# Altium schematic sheet HAL_2.SchDoc
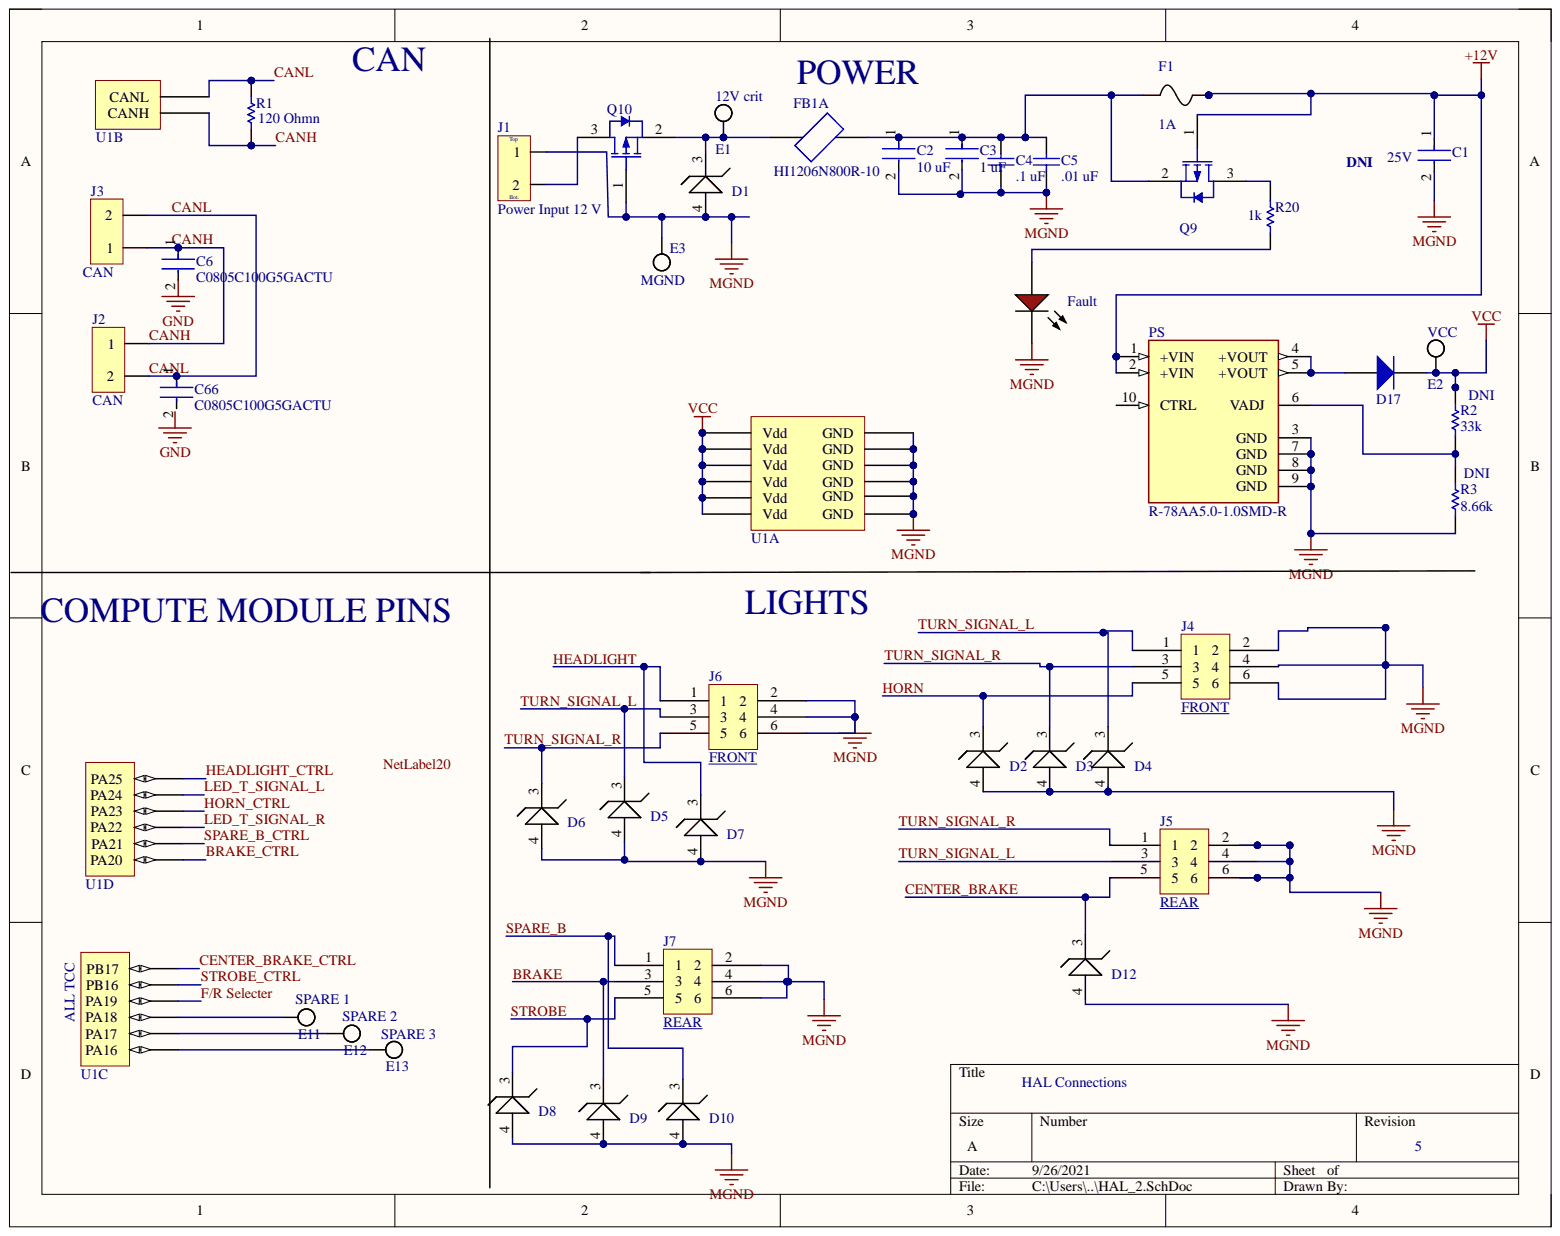
[diagram p0: HAL_2.SchDoc]

## Parts
C1  25V  [FP-GRM21B-0_2-e0_2_0_7-IPC_B]
C2  10 uF  [FP-CL31-IPC_A]
C3  1 uF  [FP-CL31-IPC_A]
C4  .1 uF  [CAPC2013X140X50LL20T25]
C5  .01 uF  [CAPC1608X90X35LL15T15]
C6  C0805C100G5GACTU  [FP-C0805C-DN-MFG]
C66  C0805C100G5GACTU  [FP-C0805C-DN-MFG]
D1  TVS DIODE 12.4V 20.1V DO219AB  [TVS DIODE 12.4V 20.1V DO219AB]  (value not drawn)
D2  TVS DIODE 12.4V 20.1V DO219AB  [TVS DIODE 12.4V 20.1V DO219AB]  (value not drawn)
D3  TVS DIODE 12.4V 20.1V DO219AB  [TVS DIODE 12.4V 20.1V DO219AB]  (value not drawn)
D4  TVS DIODE 12.4V 20.1V DO219AB  [TVS DIODE 12.4V 20.1V DO219AB]  (value not drawn)
D5  TVS DIODE 12.4V 20.1V DO219AB  [TVS DIODE 12.4V 20.1V DO219AB]  (value not drawn)
D6  TVS DIODE 12.4V 20.1V DO219AB  [TVS DIODE 12.4V 20.1V DO219AB]  (value not drawn)
D7  TVS DIODE 12.4V 20.1V DO219AB  [TVS DIODE 12.4V 20.1V DO219AB]  (value not drawn)
D8  TVS DIODE 12.4V 20.1V DO219AB  [TVS DIODE 12.4V 20.1V DO219AB]  (value not drawn)
D9  TVS DIODE 12.4V 20.1V DO219AB  [TVS DIODE 12.4V 20.1V DO219AB]  (value not drawn)
D10  TVS DIODE 12.4V 20.1V DO219AB  [TVS DIODE 12.4V 20.1V DO219AB]  (value not drawn)
D12  TVS DIODE 12.4V 20.1V DO219AB  [TVS DIODE 12.4V 20.1V DO219AB]  (value not drawn)
D17  1N4001-T  [DIOD-DO-41-P-2_V]  (value not drawn)
E1  12V crit  [EPAD]  (no BOM)
E2  VCC  [EPAD]  (no BOM)
E3  MGND  [EPAD]  (no BOM)
E11  SPARE 1  [EPAD]  (no BOM)
E12  SPARE 2  [EPAD]  (no BOM)
E13  SPARE 3  [EPAD]  (no BOM)
F1  1A  [OMNIBLOCK FUSE HOLDER]
Fault  HSMH-C150  [AVAG-HSMX-C150_V]  (value not drawn)
FB1  HI1206N800R-10  [FB_HI1206N800R-10]  (part A)
J1  Power Input 12 V  [CONN_2P_MEGAFIT_HORIZONTAL]
J2  CAN  [Mini-Fit Jr. 2]
J3  CAN  [Mini-Fit Jr. 2]
J4  FRONT  [PCBComponent_1]
J5  REAR  [PCBComponent_1]
J6  FRONT  [PCBComponent_1]
J7  REAR  [PCBComponent_1]
PS  R-78AA5.0-1.0SMD-R  [CONV_R-78AA5.0-1.0SMD-R]
Q9  IRLML6402TRPBF  [INTR-SOT-23-3_V]  (value not drawn)
Q10  IRLML6402TRPBF  [INTR-SOT-23-3_V]  (value not drawn)
R1  120 Ohmn  [RESC2013X50X40LL20T20]
R2  33k  [RESC2013X50X30LL20T20]
R3  8.66k  [RESC2013X60X35ML10T20]
R20  1k  [RESC3216X70X40LL20T20]
U1  S+  [Compute S+]  (parts A,B,C,D)

## Nets
+12V: C1.1 F1.2 PS.1(+VIN) PS.2(+VIN) Q9.1
GND: C6.2
MGND: C1.2 C2.2 C3.2 C4.1 C5.1 D1.4 D2.4 D3.4 D4.4 D5.4 D6.4 D7.4 D8.4 D9.4 D10.4 D12.4 E3.1 Fault.2 J1.1 J4.2 J4.4 J4.6 J5.2 J5.4 J5.6 J6.2 J6.4 J6.6 J7.2 J7.4 J7.6 PS.3(GND) PS.7(GND) PS.8(GND) PS.9(GND) Q10.1 R3.1 U1.GND
VCC: D17.2 E2.1 R2.2 U1.Vdd
BRAKE: D9.3 J7.3
BRAKE_CTRL: U1.PA20
CANH: C6.1 J2.1 J3.1 R1.2 U1.CANH
CANL: C66.1 J2.2 J3.2 R1.1 U1.CANL
CENTER_BRAKE: D12.3 J5.5
CENTER_BRAKE_CTRL: U1.PB17
F/R Selecter: U1.PA19
HEADLIGHT: D7.3 J6.1
HEADLIGHT_CTRL: U1.PA25
HORN: D2.3 J4.5
HORN_CTRL: U1.PA23
LED_T_SIGNAL_L: U1.PA24
LED_T_SIGNAL_R: U1.PA22
SPARE_B: D10.3 J7.1
SPARE_B_CTRL: U1.PA21
STROBE: D8.3 J7.5
STROBE_CTRL: U1.PB16
TURN_SIGNAL_L: D4.3 D5.3 J4.1 J5.3 J6.3
TURN_SIGNAL_R: D3.3 D6.3 J4.3 J5.1 J6.5
NetC2_1: C2.1 C3.1 C4.2 C5.2 F1.1 FB1.2 Q9.2
NetD1_3: D1.3 E1.1 FB1.1 Q10.2
NetD17_1: D17.1 PS.4(+VOUT) PS.5(+VOUT)
NetE11_1: E11.1 U1.PA18
NetE12_1: E12.1 U1.PA17
NetE13_1: E13.1 U1.PA16
NetFault_1: Fault.1 R20.2
NetJ1_2: J1.2 Q10.3
NetPS_6: PS.6(VADJ) R2.1 R3.2
NetQ9_3: Q9.3 R20.1
NetLabel20:

Not connected: C66 2; PS 10(CTRL)

## Library data of the parts
C1 = CMP-2007-03222-3: Chip Multilayer Ceramic Capacitors for General Purpose, 0805, 22uF, X5R, 15%, 20%, 25VGRM21BR61E226ME44L; Capacitance: 22uF; Case Code (Imperial): 0805; Case Code (Metric): 2012; Case/Package: 0805; Component Kind: Standard; Depth: 1.25mm; Dielectric: X5R; Height: 1.25mm; Lead Free: Lead Free; Length: 2mm; Library Name: Altium Content Vault; Material: Ceramic; Max Operating Temperature: 85°C; Min Operating Temperature: -55°C; Mount: Surface Mount; Package Quantity: 3000; Packaging: Tape and Reel; REACH SVHC: No SVHC; Resistor Type: Ceramic; Termination: SMD/SMT; Thickness: 0.057inch; Tolerance: 20%; Voltage: 25V; Voltage Rating: 25V; Voltage Rating (DC): 25V; Weight: 0.000335oz; Width: 1.25mm
C2 C3 = CMP-13271-002819-1: Cap Ceramic 10uF 16V X5R ±10% SMD 1206 +85°C Embossed T/R; Capacitance: 10uF; Case Code (Imperial): 1206; Case Code (Metric): 3216; Case/Package: 1206; Component Kind: Standard; Contact Plating: Tin; Depth: 1.6mm; Dielectric: X5R; Height: 1.6mm; Lead Free: Lead Free; Length: 3.2mm; Library Name: Altium Content Vault; Material: Ceramic; Max Operating Temperature: 85°C; Min Operating Temperature: -55°C; Mount: Surface Mount; Packaging: Tape and Reel; Radiation Hardening: No; Thickness: 0.071inch; Tolerance: 10%; Voltage: 16V; Voltage Rating: 16V; Voltage Rating (DC): 16V; Width: 0.063inch
C4 = CMP-2007-04740-1: Manufacturer: AVX Interconnect / Elco; Part Number: 08051C104M4Z2A; Component Kind: Standard; Library Name: Altium Content Vault
C5 = CMP-2006-04438-1: Manufacturer: AVX Interconnect / Elco; Part Number: 06035C472K4T4A; Component Kind: Standard; Library Name: Altium Content Vault
C6 C66 = CMP-03020-001866-1: C0805 10 pF C0G 30ppm/°C 2.00% 50 V; Manufacturer: KEMET; Capacitance: 10 pF; Material: Ceramic; Tolerance: 0.02; Value: 10 pF; Voltage Rating: 50 V; Automotive: No; Category: Cap; Component Kind: Standard; ComponentLink2Description: Datasheet; ComponentLink2URL: https://content.kemet.com/datasheets/KEM_C1003_C0G_SMD.pdf; Device Class L1: Passive Components; Device Class L2: Capacitors; Device Class L3: Ceramic Capacitors; Height: 0.88mm; Ipc Land Pattern Name: CAPC200125X78; Lead Free: Yes; Library Name: Altium Content Vault; Manufacturer Part Number: C0805C100G5GACTU; Mouser Description: Multilayer Ceramic Capacitors MLCC - SMD/SMT 50volts 10pF C0G 2%; Octopart: https://octopart.com/c0805c100g5gactu-kemet-20071675; Package: C0805C-DN; RoHS: TRUE; Temperature: -55°C to +125°C; Temperature Characteristic: C0G; Temperature Coefficient: 30ppm/°C; Temperature Range High: +125°C; Temperature Range Low: -55°C
D17 = CMP-0639-00006-2: 1.0 A Rectifier, 15 pF, 50 V, -65 to 150 degC, 2-Pin DO-41 Plastic, RoHS, Tape and Reel; Manufacturer: Diodes Inc; CT (pF): 15; Max. Average Rectified Current IO (A): 1; Max. IFSM (A): 30; Max. IR (uA): 5; VRRM (V): 50; Component Kind: Standard; ComponentLink1Description: Manufacturer URL; ComponentLink1URL: http://diodes.com/; ComponentLink2Description: Datasheet; ComponentLink2URL: http://diodes.com/datasheets/ds28002.pdf; DatasheetVersion: Rev. 8-2; Library Name: Altium Content Vault; Mounting Technology: Through Hole; Operating Temperature Range (degC): -65 to 150; PackageDescription: 2-Pin Axial Lead, Body 4.635 x 2.36 mm, Lead Spread 25.4 mm; PackageReference: DO-41-P-2; Packing: Tape and Reel; PartNumber: 1N4001-T; RoHS: TRUE; VF (V): 1
E1-E3 E11-E13 = EPAD: Pad for probing signals; Library Name: PrISUm-Discrete.SchLib
F1 = Fuse - OmniBlok: OmniBlok fuse Holder; Component Kind: Standard; Digikey-Fuse: http://www.digikey.com/product-detail/en/littelfuse-inc/0451001.MRL/F2577CT-ND/813055; Digikey-Socket: http://www.digikey.com/product-detail/en/littelfuse-inc/01550900M/F1889-ND/2519878; Library Name: PrISUm-Discrete.SchLib
Fault = CMP-2000-06426-1: LED Uni-Color Red, 65 mW, 25 mA, -40 to 85 degC, 2-Pin SMD, RoHS, Tape and Reel; Manufacturer: Avago; Chip Material: GaAlAs; DELETED: Compliant; Dominant Wave Length: 639nm; Forward Current: 25mA; Forward Voltage: 2.6V; High Brightness: No; Lens Type: ColorlessDiffused; Lum Intensity: 17mcd; Main Category: ChipLED; Number of  Elements: 1; Peak Wavelength: 660nm; Power Dissipation: 78W; Product Depth (mm): 1.6mm; Product Height (mm): 1.1mm; Product Length (mm): 3.2mm; Reverse Voltage: 5V; Shape Type: Rectangular; Test Current (It): 20mA; Viewing Angle: 170deg; Component Kind: Standard; Datasheet URL: http://media.digikey.com/pdf/Data%20Sheets/Avago%20PDFs/HSMz-Czzz.pdf; Datasheet Version: 03/2012; Emitting Color: Red; Lens Dimensions: 2x1.6x0.6mm; Library Name: Altium Content Vault; Luminous Intensity: 17mcd; Manufacturer URL: http://www.avagotech.com/; Mounting: SurfaceMount; Mounting Technology: SurfaceMount; Operating Temp Range: -40Cto85C; Operating Temperature Classification: Industrial; Package Description: 2-Pin Surface Mount Device, Body 3.2 x 1.6 mm; Package Reference: HSMX-C150; Package Type: ChipLed; Packaging: TapeandReel; Pin Count: 2; Rad Hardened: No; Type: Uni-Color
FB1 = HI1206N800R-10: 80 Ohms @ 100MHz 1 Power, Signal Line Ferrite Bead 1206 (3216 Metric) 3A 35mOhm; MF: Laird-Signal Integrity; Check_prices: https://www.snapeda.com/parts/HI1206N800R-10/Laird-Signal%20Integrity%20Products/view-part/296889/?ref=eda; Component Kind: Standard; Digi-Key_Part_Number: 240-2409-1-ND; MP: HI1206N800R-10; Package: 1206 Laird-Signal Integrity; Purchase-URL: https://pricing.snapeda.com/search/part/HI1206N800R-10/?ref=eda; SnapEDA_Link: https://www.snapeda.com/parts/HI1206N800R-10/Laird-Signal%20Integrity%20Products/view-part/296889/?ref=snap; Library Name: HAL and MotorBoard.SchLib
J1 = CONN_2P_MEGAFIT_HORIZONTAL: Manufacturer: Molex; Manufacturer Part Number: 0768250002; SchematicInformation: MegaFit_2P; Component Kind: Standard; DigiKey Part Number: WM11969-ND; Library Name: Connectors.IntLib
J2 J3 = Mini-Fit Jr. 2-pin: Mini-Fit Jr. 2-pin Right Angle Mini-Fit Jr. 2-pin; Manufacturer: Molex Inc; Manufacturer Part Number: 0039300020; Connector Type: Header, Shrouded; Number of Positions: 2; Number of Positions Loaded: All; Row Spacing: 0.165" (4.20mm); Category: Connectors, Interconnects; Color: Natural; Component Kind: Standard; ComponentLink1Description: http://www.molex.com/pdm_docs/sd/039300020_sd.pdf; ComponentLink1URL: http://www.molex.com/pdm_docs/sd/039300020_sd.pdf; Contact Finish: Tin; Contact Finish Thickness: 200µin (5.08µm); Contact Mating Length: -; Contact Type: Male Pin; Datasheet: http://www.molex.com/pdm_docs/sd/039301020_sd.pdf; Fastening Type: Locking Ramp; Features: Board Lock; Library Name: PrISUm-Connectors.SchLib; Mounting Type: Through Hole, Right Angle; Number of Rows: 2; Ordering: http://search.digikey.com/scripts/DkSearch/dksus.dll?Detail&name=WM1351-ND; Packaging: Tray; Pitch: -; Pricing 1: 1=0.77, 10=0.674, 50=0.6078, 100=0.5815, 250=0.5286, 500=0.47574, 1000=0.42288, 2500=0.38324, 5000=0.37002 (USD); RoHS: RoHS Compliant; Stock 1: 371; Supplier 1: Digi-Key; Termination: Solder
J4-J7 = 6 pin Megafit: 768250006-https://www.digikey.com/en/products/detail/molex/0768250006/5639613?s=N4IgTCBcDaIOwDYAcYCsAGTCQF0C%2BQA; Component Kind: Standard; ComponentLink1Description: New link; ComponentLink1URL: *https://www.digikey.com/en/products/detail/molex/0768250006/5639613?s=N4IgTCBcDaIOwDYAcYCsAGTCQF0C%2BQA; Library Name: Megafit- 6 pin.IntLib
PS = R-78AA5.0-1.0SMD-R: 1A DC/DC-Converter 'INNOLINE' SMD reg; MF: Recom Power; Component Kind: Standard; Digi-Key_Part_Number: 945-1044-1-ND; DigiKey-Purchase-URL: https://snapeda.com/shop?store=DigiKey&id=480105; Library Name: PrISUm-ICs.SchLib; Mouser-Purchase-URL: https://snapeda.com/shop?store=Mouser&id=480105; MP: R-78AA5.0-1.0SMD-R; Package: SMD-10 Recom Power; SnapEDA_Link: https://www.snapeda.com/parts/R-78AA5.0-1.0SMD-R/Recom%20Power/view-part/480105/?ref=snap
Q9 Q10 = CMP-2000-04987-1: HEXFET(R) Power P-Channel MOSFET, -20 V, -3.7 A, -55 to 150 degC, 3-Pin SOT-23, RoHS, Tape and Reel; Manufacturer: International Rectifier; Drain-Source On Resistance-Max: 0.065O; Drain-to-Source Voltage [Vdss]: 20V; Qg Gate Charge: 8nC; Rated Power Dissipation: 1.3W; Channel Type: P-Channel; Component Kind: Standard; Datasheet URL: http://datasheet.octopart.com/IRLML6402TRPBF-International-Rectifier-datasheet-93882.pdf; Datasheet Version: Feb-05; Library Name: Altium Content Vault; Manufacturer URL: http://internationalrectifier.com/; Package Description: 3-Pin Small Outline Transistor, Body 2.92 x 1.3 mm, Pitch 0.95 mm; Package Reference: SOT-23-3
R1 = CMP-2001-03236-1: RR1220P-121-D; Manufacturer: Susumu; Component Kind: Standard; Library Name: Altium Content Vault; Part Number: RR1220P-121-D
R2 = CMP-2001-03828-1: CRCW080533K0JNEA; Manufacturer: Vishay Dale; Component Kind: Standard; Library Name: Altium Content Vault; Part Number: CRCW080533K0JNEA
R3 = CMP-2001-01296-1: RC0805FR-078K66L; Manufacturer: Yageo; Component Kind: Standard; Library Name: Altium Content Vault; Part Number: RC0805FR-078K66L
R20 = CMP-2003-04517-1: RK73B2BTTD102J; Manufacturer: KOA Speer; Component Kind: Standard; Library Name: Altium Content Vault; Part Number: RK73B2BTTD102J
U1 = S+: Need to get 4 of PPPC161LFBN-RC; Component Kind: Standard; datasheet: https://media.digikey.com/pdf/Data%20Sheets/Sullins%20PDFs/Female_Headers.100_DS.pdf; Digi-key: https://www.digikey.com/product-detail/en/sullins-connector-solutions/PPPC161LFBN-RC/S7049-ND/810188; Library Name: PrISUm-ICs.SchLib
D1-D10 D12 = TVS DIODE 12.4V 20.1V DO219AB: Component Kind: Standard; ComponentLink1Description: Digikey; ComponentLink1URL: https://www.digikey.com/product-detail/en/vishay-semiconductor-diodes-division/VTVS12ASMF-M3-08/112-VTVS12ASMF-M3-08CT-ND/7055981; ComponentLink2Description: Datasheet; ComponentLink2URL: http://www.vishay.com/docs/85891/vtvs3v3asmf.pdf; Library Name: HAL and MotorBoard.SchLib
